# Altium schematic sheet PowerSupply.SchDoc(PowerSupply)
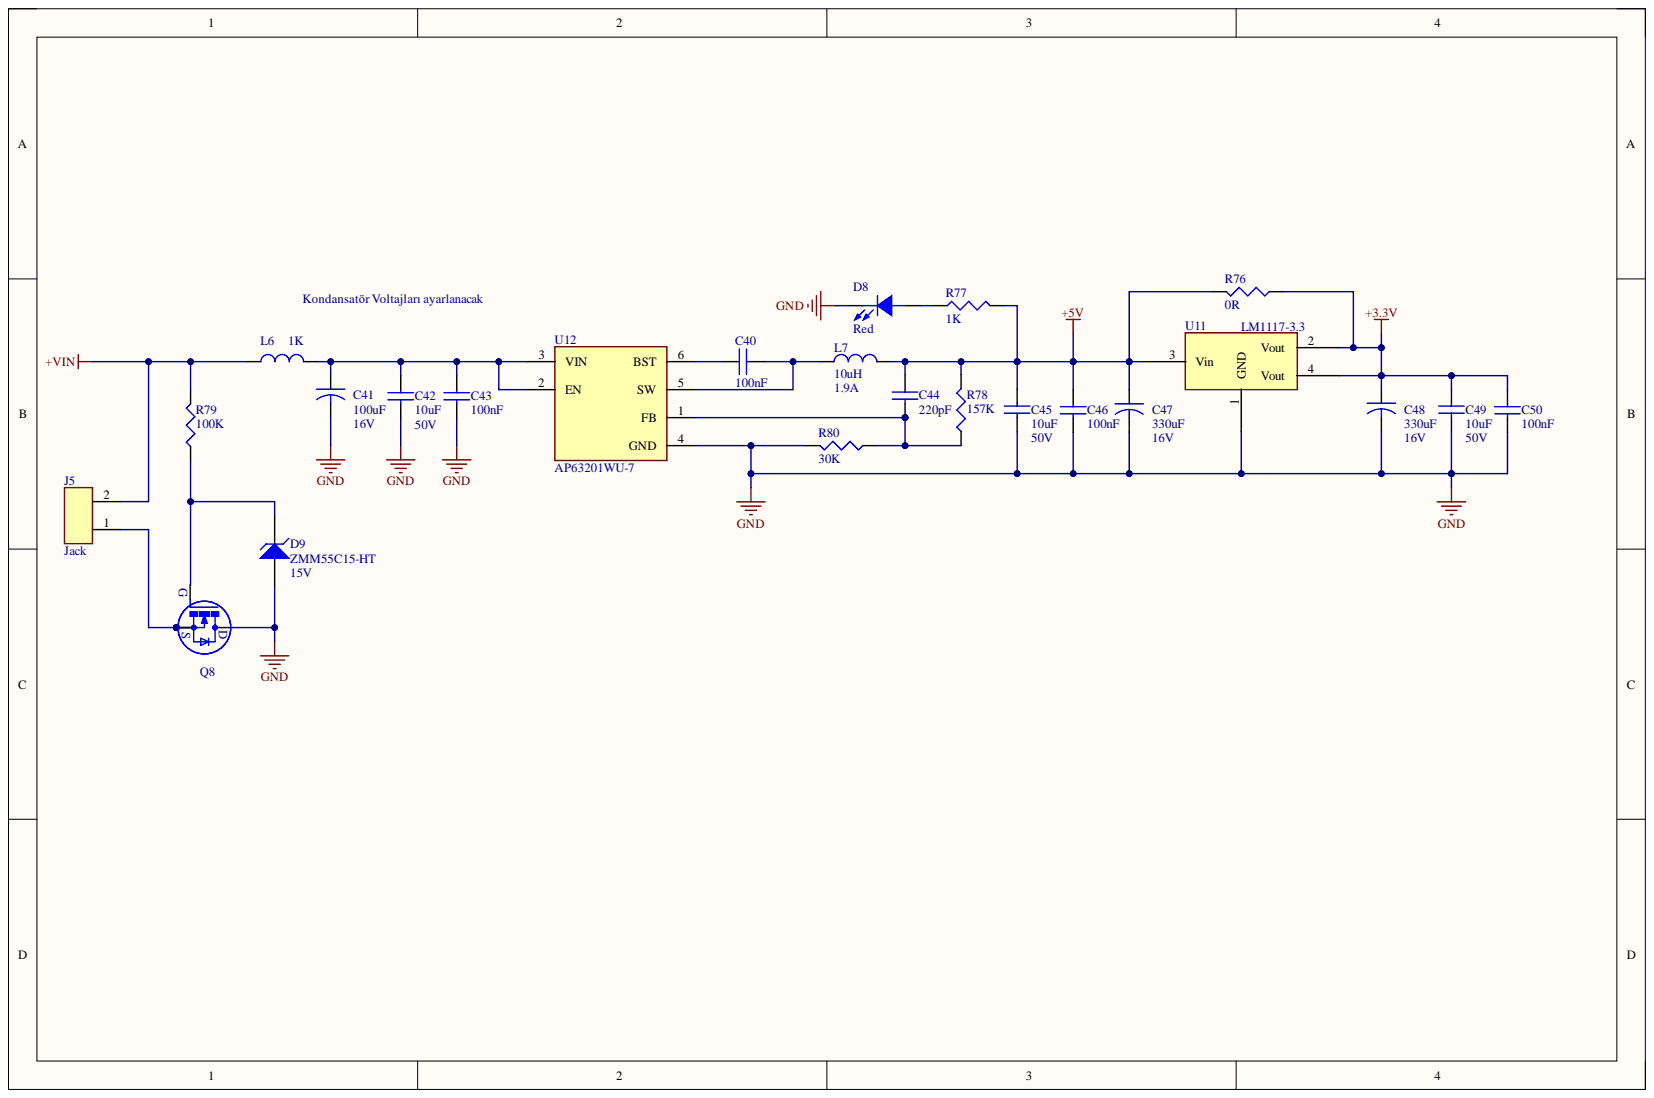
[diagram p0: PowerSupply.SchDoc]

## Parts
C40  value 100nF  [0805 SMD Ceramic Capacitor]  (hidden comment: C0805C104)
C41  value 100uF  Voltage: 16V  [D Size]  (hidden comment: Electrolitic 100uF/16V)
C42  value 10uF  Voltage: 50V  [1206 SMD Ceramic Capacitor]  (hidden comment: C1206C106)
C43  value 100nF  [0805 SMD Ceramic Capacitor]  (hidden comment: C0805C104)
C44  value 220pF  [0805 SMD Ceramic Capacitor]  (hidden comment: C0805C221)
C45  value 10uF  Voltage: 50V  [1206 SMD Ceramic Capacitor]  (hidden comment: C1206C106)
C46  value 100nF  [0805 SMD Ceramic Capacitor]  (hidden comment: C0805C104)
C47  value 330uF  Voltage: 16V  [D Size]  (hidden comment: Electrolitic 100uF/16V)
C48  value 330uF  Voltage: 16V  [D Size]  (hidden comment: Electrolitic 100uF/16V)
C49  value 10uF  Voltage: 50V  [1206 SMD Ceramic Capacitor]  (hidden comment: C1206C106)
C50  value 100nF  [0805 SMD Ceramic Capacitor]  (hidden comment: C0805C104)
D8  value Red  [0805 RED LED]  (hidden comment: 0805 Red LED)
D9  ZMM55C15-HT  Voltage: 15V  [SOD80C]
J5  value Jack  [KF2EDGV-5.08-2P]  (hidden comment: KF2EDGV-5.08-2P)
L6  value 1K  [0805 Inductor]  (hidden comment: 0805Ferrite)
L7  value 10uH  Current: 1.9A  [6x6mm_Inductor]  (hidden comment: 10uH_1900mA)
Q8  N-CH Mosfet  [PowerPak SO-8]  (value not drawn)
R76  value 0R  [0805 Resistor]  (hidden comment: 0805_0R)
R77  value 1K  [0805 Resistor]  (hidden comment: 0805_1K)
R78  value 157K  [0805 Resistor]  (hidden comment: 0805_0R)
R79  value 100K  [0805 Resistor]  (hidden comment: 0805_100K%1)
R80  value 30K  [0805 Resistor]  (hidden comment: 0805_0R)
U11  LM1117-3.3  [SOT223]
U12  AP63201WU-7  [SOT23-6]

## Nets
+3.3V: C48.1 C49.1 C50.1 R76.1 U11.2(Vout) U11.4(Vout)
+5V: C44.1 C45.1 C46.1 C47.1 L7.2 R76.2 R77.2 R78.2 U11.3(Vin)
+VIN: J5.2 L6.1 R79.2
GND: C41.2 C42.2 C43.2 C45.2 C46.2 C47.2 C48.2 C49.2 C50.2 D8.2 D9.2 Q8.5 R80.2 U11.1(GND) U12.4(GND)
NetC40_1: C40.1 U12.6(BST)
NetC40_2: C40.2 L7.1 U12.5(SW)
NetC41_1: C41.1 C42.1 C43.1 L6.2 U12.2(EN) U12.3(VIN)
NetC44_2: C44.2 R78.1 R80.1 U12.1(FB)
NetD8_1: D8.1 R77.1
NetD9_1: D9.1 Q8.4 R79.1
NetJ5_1: J5.1 Q8.1 Q8.2 Q8.3

## Library data of the parts
C40 C43 C46 C50 = C0805C104: Manufacturer: KEMET; Manufacturer Code: C0805C104J5RACTU; Voltage: 50V; Component Kind: Standard; Farnell Code: 1414663; Library Name: Capacitors.SchLib; Package: 0805; Unit Price: 0,06 €
C41 C47 C48 = Electrolitic 100uF/16V: Manufacturer: Panasonic; Manufacturer Code: EEEHA1C01WP; Component Kind: Standard; Farnell Code: 1973308; Library Name: Capacitors.SchLib; Unit Price: 0.47 €
C42 C45 C49 = C1206C106: Manufacturer: MULTICOMP; Manufacturer Code: MC1206B475K500CT; Capacitance Tolerance: ±10%; Component Kind: Standard; Farnell Code: 2320908; Library Name: Capacitors.SchLib; MOQ: 10; Unit Price: 0,644 €
C44 = C0805C221: Manufacturer: YAGEO; Manufacturer Code: CC0805JRNPO0BN221; Tolerace: ± 5%; Voltage: 100V; Component Kind: Standard; Library Name: Capacitors.SchLib; Package: 0805; Price Unit: $; Unit Price: 0,00832
D8 = 0805 Red LED: Manufacturer: KINGBRIGHT; Manufacturer Code: KPT-2012EC; Current: 20mA; Voltage: 2.5V; Component Kind: Standard; Farnell Code: 2099296; Library Name: LED.SchLib; Unit Price: 0,065 €
D9 = ZMM55C15-HT: DIODE ZENER 500mW 15V ±5% SOD80 (MINIMELF) SMT; Manufacturer: HOTTECH; Power: 0.5W; Component Kind: Standard; Library Name: ZenerDiode.SchLib; Manufacturer Code: ZMM55C15-HT; Package: SOD80; Unit Price: 0.011; Value: ZMM55C15-HT
J5 = KF2EDGV-5.08-2P: TERM BLOCK HDR 2POS 5.08mm; Manufacturer: Cixi Kefa Elec; Component Kind: Standard; Digikey Code: None; Library Name: Terminal.SchLib; Manufacturer Code: KF2EDGV-5.08-2P; Unit Price: 0.0417
L6 = 0805Ferrite: Manufacturer: TDK; Manufacturer Code: MMZ2012R102A; Current: 500mA; Component Kind: Standard; Farnell Code: 1669719; Library Name: FerriteBead.SchLib; Unit Price: 0,059 €
L7 = 10uH_1900mA: INDUCTOR POWER 10UH 6X6MM 1.9A SMD; Manufacturer: CORE MASTER; Manufacturer Code: NR6028-100M; Component Kind: Standard; Digikey Code: None; Library Name: Inductors.SchLib; Unit Price: 0.17
Q8 = N-CH Mosfet: Current: 9.4A; RDS: 0.21R; Component Kind: Standard; Library Name: MOSFET.SchLib
R76 R78 R80 = 0805_0R: Manufacturer: MULTICOMP; Manufacturer Code: MC 0.1W 0805 0R; Component Kind: Standard; Farnell Code: 9333681; Library Name: Resistors.SchLib; Unit Price: 0,028 €
R77 = 0805_1K: Manufacturer: MULTICOMP; Manufacturer Code: MC01W080511K; Tolerance: ±1%; Component Kind: Standard; Farnell Code: 9332383; Library Name: Resistors.SchLib; Unit Price: 0,03 €
R79 = 0805_100K%1: Manufacturer: MULTICOMP; Manufacturer Code: MC01W08051100K; Tolerance: ±1%; Component Kind: Standard; Farnell Code: 9332405; Library Name: Resistors.SchLib; Unit Price: 0,03 €
U11 = LM1117-3.3: Manufacturer: Texas Instruments; Manufacturer Code: LM1117MP-3.3; Current: 800mA; Voltage: 3.3V; Component Kind: Standard; Farnell Code: 9778195; Library Name: LM1117.SchLib; Unit Price: 1,14 €; Value: LM1117-3.3
U12 = AP63201WU-7: Buck Switching Regulator IC Positive Adjustable 1.2V 1 Output 2A SOT-23-6 Thin, TSOT-23-6; Manufacturer: Diodes Incorporated; Component Kind: Standard; Digikey Code: AP63201WU-7DICT-ND; Library Name: AP63201WU-7.SchLib; Manufacturer Code: AP63201WU-7; Package: SOT23-6; Unit Price: 0.73; Value: AP63201WU-7
